# Altium schematic sheet ARK_Cannode_Microcontroller.SchDoc(ARK_Cannode_Microcontroller)
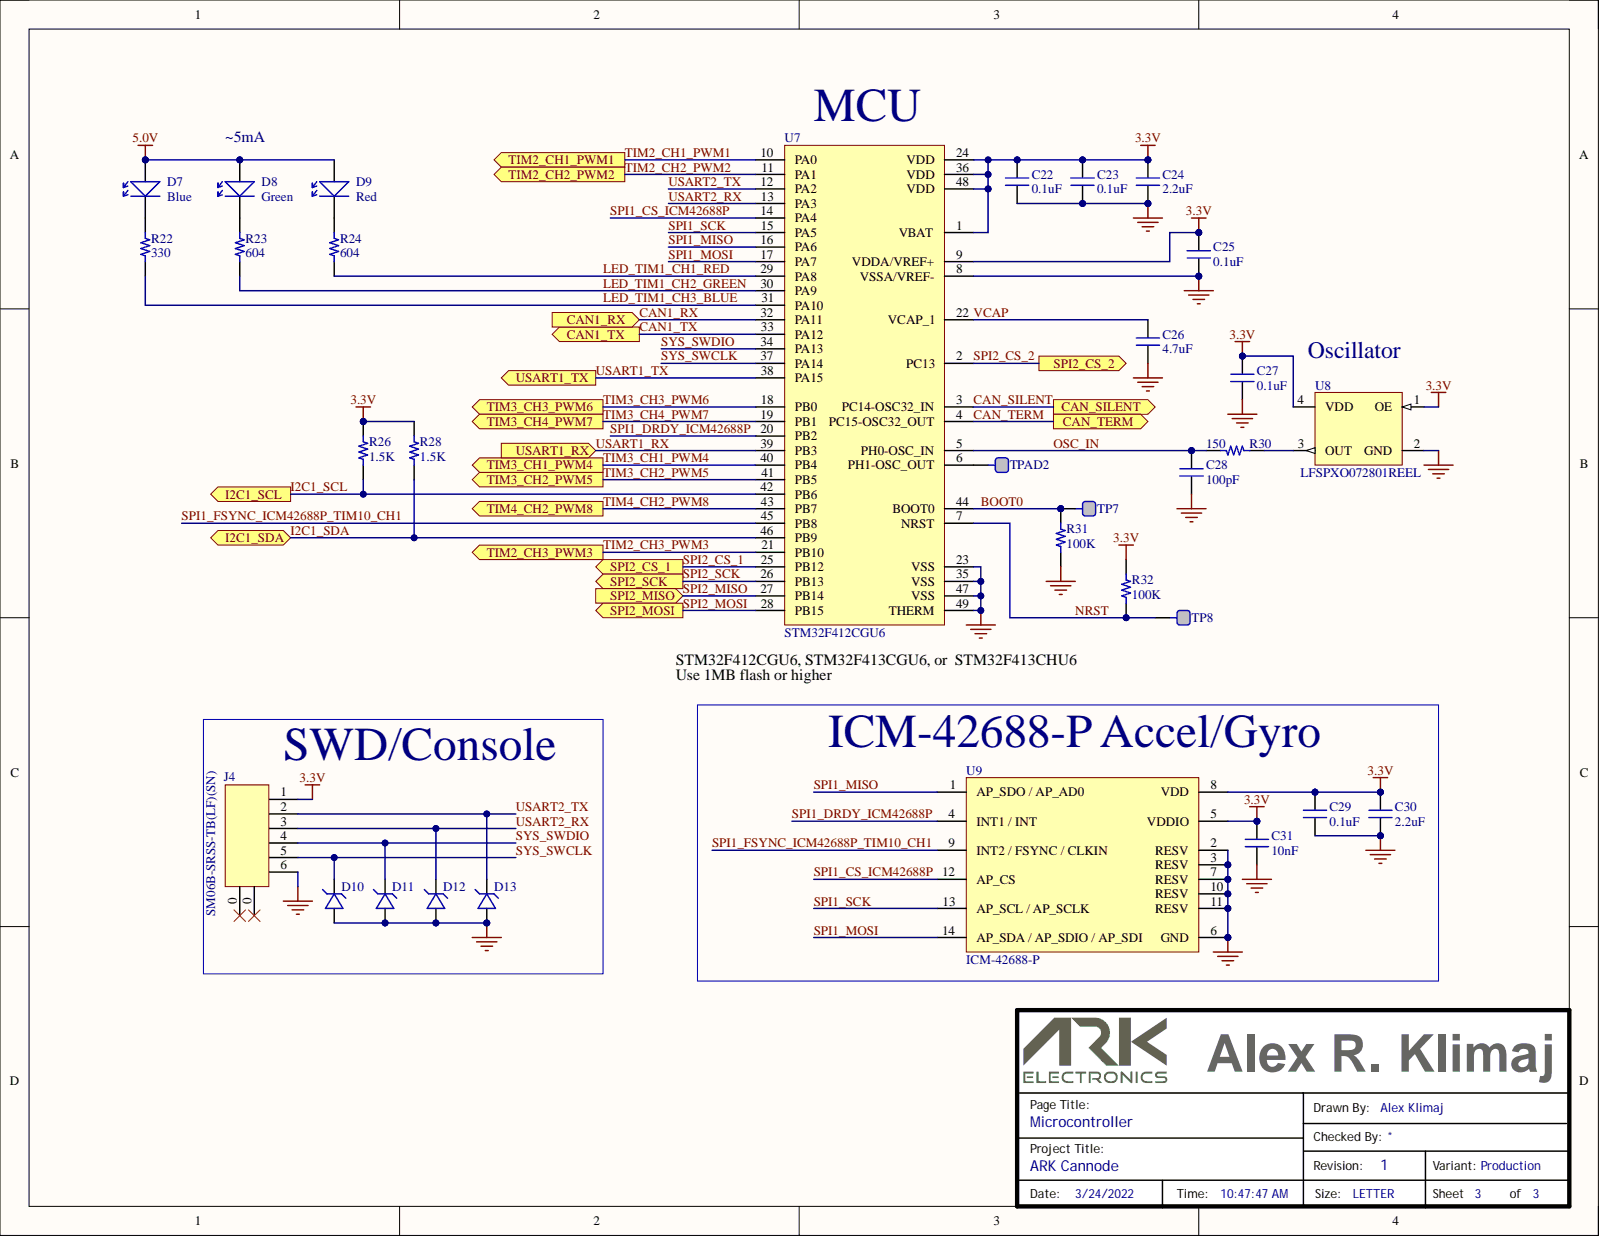
[diagram p0: ARK_Cannode_Microcontroller.SchDoc]

## Parts
C22  value 0.1uF  [CAP 0402/1005]
C23  value 0.1uF  [CAP 0402/1005]
C24  2.2uF  [CAP 0402/1005]
C25  value 0.1uF  [CAP 0402/1005]
C26  4.7uF  [CAP 0402/1005]
C27  value 0.1uF  [CAP 0402/1005]
C28  100pF  [CAP 0402/1005]
C29  value 0.1uF  [CAP 0402/1005]
C30  2.2uF  [CAP 0402/1005]
C31  10nF  [CAP 0402/1005]
D7  LTST-C194TBKT  [LTST-C191TBKT]  (value not drawn)
D8  LTST-C191KGKT  [LTST-C191TBKT]  (value not drawn)
D9  LTST-C190KRKT  [LTST-C191TBKT]  (value not drawn)
D10  (no value)  [SOD-923]
D11  (no value)  [SOD-923]
D12  (no value)  [SOD-923]
D13  (no value)  [SOD-923]
J4  value SM06B-SRSS-TB(LF)(SN)  [SM06B-SRSS-TB(LF)(SN)]
R22  330  [RESC0402(1005)_N]
R23  604  [RESC0402(1005)_N]
R24  604  [RESC0402(1005)_N]
R26  1.5K  [RESC0402(1005)_N]
R28  1.5K  [RESC0402(1005)_N]
R30  150  [RESC0402(1005)_N]
R31  100K  [RESC0402(1005)_N]
R32  100K  [RESC0402(1005)_N]
TP7  (no value)  [TEST_PAD]  (no BOM)
TP8  (no value)  [TEST_PAD]  (no BOM)
TPAD2  (no value)  [TEST_PAD]  (no BOM)
U7  STM32F412CGU6  Sub_Type: MCU  [UFQFPN48]
U8  LFSPXO072801REEL  Voltage_Rating: 3.3V  [LFSPXO072801REEL]
U9  ICM-42688-P  [ICM-42688-P]

## Ports
CAN1_RX, CAN1_TX, CAN_SILENT, CAN_TERM, I2C1_SCL, I2C1_SDA, SPI2_CS_1, SPI2_CS_2, SPI2_MISO, SPI2_MOSI, SPI2_SCK, TIM2_CH1_PWM1, TIM2_CH2_PWM2, TIM2_CH3_PWM3, TIM3_CH1_PWM4, TIM3_CH2_PWM5, TIM3_CH3_PWM6, TIM3_CH4_PWM7, TIM4_CH2_PWM8, USART1_RX, USART1_TX

## Nets
3.3V: C22.2 C23.2 C24.2 C25.2 C27.2 C29.2 C30.2 C31.2 J4.1 R26.2 R28.2 R32.2 U7.1(VBAT) U7.9(VDDA/VREF+) U7.24(VDD) U7.36(VDD) U7.48(VDD) U8.1(OE) U8.4(VDD) U9.5(VDDIO) U9.8(VDD)
5.0V: D7.2 D8.2 D9.2
GND: C22.1 C23.1 C24.1 C25.1 C26.1 C27.1 C28.1 C29.1 C30.1 C31.1 D10.2 D11.2 D12.2 D13.2 J4.6 R31.1 U7.8(VSSA/VREF-) U7.23(VSS) U7.35(VSS) U7.47(VSS) U7.49(THERM) U8.2(GND) U9.2(RESV) U9.3(RESV) U9.6(GND) U9.7(RESV) U9.10(RESV) U9.11(RESV)
BOOT0: R31.2 TP7.1 U7.44(BOOT0)
CAN1_RX: U7.32(PA11) ; port CAN1_RX
CAN1_TX: U7.33(PA12) ; port CAN1_TX
CAN_SILENT: U7.3(PC14-OSC32_IN) ; port CAN_SILENT
CAN_TERM: U7.4(PC15-OSC32_OUT) ; port CAN_TERM
I2C1_SCL: R26.1 U7.42(PB6) ; port I2C1_SCL
I2C1_SDA: R28.1 U7.46(PB9) ; port I2C1_SDA
LED_TIM1_CH1_RED: R24.1 U7.29(PA8)
LED_TIM1_CH2_GREEN: R23.1 U7.30(PA9)
LED_TIM1_CH3_BLUE: R22.1 U7.31(PA10)
NRST: R32.1 TP8.1 U7.7(NRST)
OSC_IN: C28.2 R30.1 U7.5(PH0-OSC_IN)
SPI1_CS_ICM42688P: U7.14(PA4) U9.12(AP_CS)
SPI1_DRDY_ICM42688P: U7.20(PB2) U9.4(INT1_/_INT)
SPI1_FSYNC_ICM42688P_TIM10_CH1: U7.45(PB8) U9.9
SPI1_MISO: U7.16(PA6) U9.1(AP_SDO_/_AP_AD0)
SPI1_MOSI: U7.17(PA7) U9.14
SPI1_SCK: U7.15(PA5) U9.13(AP_SCL_/_AP_SCLK)
SPI2_CS_1: U7.25(PB12) ; port SPI2_CS_1
SPI2_CS_2: U7.2(PC13) ; port SPI2_CS_2
SPI2_MISO: U7.27(PB14) ; port SPI2_MISO
SPI2_MOSI: U7.28(PB15) ; port SPI2_MOSI
SPI2_SCK: U7.26(PB13) ; port SPI2_SCK
SYS_SWCLK: D10.1 J4.5 U7.37(PA14)
SYS_SWDIO: D11.1 J4.4 U7.34(PA13)
TIM2_CH1_PWM1: U7.10(PA0) ; port TIM2_CH1_PWM1
TIM2_CH2_PWM2: U7.11(PA1) ; port TIM2_CH2_PWM2
TIM2_CH3_PWM3: U7.21(PB10) ; port TIM2_CH3_PWM3
TIM3_CH1_PWM4: U7.40(PB4) ; port TIM3_CH1_PWM4
TIM3_CH2_PWM5: U7.41(PB5) ; port TIM3_CH2_PWM5
TIM3_CH3_PWM6: U7.18(PB0) ; port TIM3_CH3_PWM6
TIM3_CH4_PWM7: U7.19(PB1) ; port TIM3_CH4_PWM7
TIM4_CH2_PWM8: U7.43(PB7) ; port TIM4_CH2_PWM8
USART1_RX: U7.39(PB3) ; port USART1_RX
USART1_TX: U7.38(PA15) ; port USART1_TX
USART2_RX: D12.1 J4.3 U7.13(PA3)
USART2_TX: D13.1 J4.2 U7.12(PA2)
VCAP: C26.2 U7.22(VCAP_1)
NetD7_1: D7.1 R22.2
NetD8_1: D8.1 R23.2
NetD9_1: D9.1 R24.2
NetR30_2: R30.2 U8.3(OUT)
NetTPAD2_1: TPAD2.1 U7.6(PH1-OSC_OUT)

Not connected: J4 0

## Library data of the parts
C22 C23 C25 C27 C29 = 30007: SMT Capacitor; Manufacturer: Walsin Technology Corporation; Mfr Part Description: CAP CER 0.1uF ±10% 25V X5R 0402 (1005 Metric); Mfr Part Number: 0402X104K250CT; Database Table Name: Parts; Dielectric: X5R; Tolerance: ±10%; Updated By: aklimaj; Voltage_Rating: 25V; Component Kind: Standard; ComponentLink1Description: Datasheet; ComponentLink1URL: http://www.passivecomponent.com/wp-content/uploads/2018/10/MLCC.pdf; ComponentLink2Description: Supplier Part Details; ComponentLink2URL: https://www.digikey.com/products/en?keywords=0402X104K250CT; Created_Date: 2017/07/11 17:31:38; ID: 30007; Library Name: Parts.DbLib; LifeCycle: New; Package_Case: 0402 (1005 Metric); Part_Type: Capacitor; RoHS: Yes; Supplier: Digi-Key; Supplier Part Number: 1292-1640-2-ND; Temperature Range: -55C to +85C; Updated_Date: 2020/12/17 20:30:00; Created By: aklimaj
C24 C30 = 30151: SMT Capacitor; Manufacturer: Murata; Manufacturer Alt 1: Taiyo Yuden; Mfr Part Description: CAP CER 2.2uF ±20% 10V X5R 0402 (1005 Metric); Mfr Part Number: GRM155R61A225ME95D; Mfr Part Number Alt 1: LMK105BJ225MV-F; Database Table Name: Parts; Dielectric: X5R; Tolerance: ±20%; Updated By: aklimaj; Voltage_Rating: 10V; Component Kind: Standard; ComponentLink1Description: Datasheet; ComponentLink1URL: http://search.murata.co.jp/Ceramy/image/img/A01X/partnumbering_e_01.pdf; ComponentLink2Description: Supplier Part Details; ComponentLink2URL: https://www.digikey.com/product-detail/en/murata-electronics-north-america/GRM155R61A225ME95D/490-10452-2-ND/5027537; Created_Date: 2017/11/02 14:41:38; ID: 30151; Library Name: Parts.DbLib; LifeCycle: New; Package_Case: 0402 (1005 Metric); Part_Type: Capacitor; RoHS: Yes; Supplier: Digi-Key; Supplier Part Number: 490-10452-2-ND; Temperature Range: -55C to +85C; Updated_Date: 2021/09/10 21:38:25; Value: 2.2uF; Created By: aklimaj
C26 = 30302: SMT Capacitor; Manufacturer: TDK Corporation; Manufacturer Alt 1: Venkel; Mfr Part Description: CAP CER 4.7uF ±10% 6.3V X5R 0402 (1005 Metric); Mfr Part Number: C1005X5R0J475K050BC; Mfr Part Number Alt 1: C0402X5R6R3-475KNP-CT; Database Table Name: Parts; Dielectric: X5R; Tolerance: ±10%; Updated By: aklimaj; Voltage_Rating: 6.3V; Component Kind: Standard; ComponentLink1Description: Datasheet; ComponentLink1URL: https://product.tdk.com/info/en/catalog/datasheets/mlcc_commercial_general_en.pdf; ComponentLink2Description: Supplier Part Details; ComponentLink2URL: https://www.digikey.com/products/en?keywords=445-5947-1-ND&stock=1; Created_Date: 2019/02/28 17:07:10; ID: 30302; Library Name: Parts.DbLib; LifeCycle: New; Manufacturer Alt 2: AVX Corporation; Mfr Part Number Alt 2: 04026D475KAT2A; Package_Case: 0402 (1005 Metric); Part_Type: Capacitor; RoHS: Yes; Supplier: Digi-Key; Supplier Part Number: 445-5947-1-ND; Temperature Range: -55C to +85C; Updated_Date: 2021/08/05 14:56:58; Value: 4.7uF; Created By: aklimaj
C28 = 30502: SMT Capacitor; Manufacturer: Samsung Electro-Mechanics; Mfr Part Description: CAP CER 100pF ±5% 50V NPO 0402 (1005 Metric); Mfr Part Number: CL05C101JB5NNNC; Database Table Name: Parts; Dielectric: NPO; Tolerance: ±5%; Updated By: aklimaj; Voltage_Rating: 50V; Component Kind: Standard; ComponentLink1Description: Datasheet; ComponentLink1URL: http://www.samsungsem.com/kr/support/product-search/mlcc/CL05C101JB5NNNC.jsp; ComponentLink2Description: Supplier Part Details; ComponentLink2URL: https://www.digikey.com/product-detail/en/samsung-electro-mechanics/CL05C101JB5NNNC/1276-1025-1-ND/3889111; Created_Date: 2019/07/13 19:13:16; ID: 30502; Library Name: Parts.DbLib; LifeCycle: New; Package_Case: 0402 (1005 Metric); Part_Type: Capacitor; RoHS: Yes; Supplier: Digi-Key; Supplier Part Number: 1276-1025-1-ND; Temperature Range: -55C to +125C; Updated_Date: 2021/09/10 11:27:15; Value: 100pF; Created By: aklimaj
C31 = 30018: SMT Capacitor; Manufacturer: Samsung; Manufacturer Alt 1: YAGEO; Mfr Part Description: CAP CER 10000pF ±10% 25V X7R 0402 (1005 Metric); Mfr Part Number: CL05B103KA5NNNC; Mfr Part Number Alt 1: CC0402KRX7R8BB103; Database Table Name: Parts; Dielectric: X7R; Tolerance: ±10%; Updated By: aklimaj; Voltage_Rating: 25V; Component Kind: Standard; ComponentLink1Description: Datasheet; ComponentLink1URL: http://www.samsungsem.com/kr/support/product-search/mlcc/__icsFiles/afieldfile/2016/08/18/S_CL05B103KA5NNNC.pdf; ComponentLink2Description: Supplier Part Details; ComponentLink2URL: https://www.digikey.com/product-detail/en/samsung-electro-mechanics-america-inc/CL05B103KA5NNNC/1276-1057-1-ND/3889143; Created_Date: 2017/07/13 10:07:08; ID: 30018; Library Name: Parts.DbLib; LifeCycle: New; Package_Case: 0402 (1005 Metric); Part_Type: Capacitor; RoHS: Yes; Supplier: Digi-Key; Supplier Part Number: 1276-1057-1-ND; Temperature Range: -55C to +125C; Updated_Date: 2021/09/15 13:17:34; Value: 10nF; Created By: aklimaj
D7 = 30059: LTST-C191TBKT LED BLUE CLEAR 0603 SMD; Manufacturer: Lite-On Inc.; Mfr Part Description: LED BLUE CLEAR SMD; Part_Type: Discrete; Current_Rating: 20mA; Database Table Name: Parts; Notes: Was Mfr P/N LTST-C191TBKT; Updated By: aklimaj; Voltage_Rating: 3.3V; Component Kind: Standard; ComponentLink1Description: Datasheet; ComponentLink1URL: https://optoelectronics.liteon.com/upload/download/DS22-2010-0025/LTST-C194TBKT.PDF; ComponentLink2Description: Supplier Part Details; ComponentLink2URL: https://www.digikey.com/products/en?keywords=LTST-C194TBKT; Created_Date: 2017/09/05 17:40:57; ID: 30059; Library Name: Parts.DbLib; LifeCycle: New; Mfr Part Number: LTST-C194TBKT; Package_Case: 0603 (1608 Metric); RoHS: Yes; Supplier: Digi-Key; Supplier Part Number: 160-1837-2-ND; Updated_Date: 2021/09/10 21:26:14; Value: LTST-C194TBKT; Created By: aklimaj
D8 = 30628: LTST-C191TBKT LED BLUE CLEAR 0603 SMD; Manufacturer: Lite-On Inc.; Manufacturer Alt 1: Wurth; Mfr Part Description: Green 571nm LED Indication - Discrete 2V 0603 (1608 Metric); Mfr Part Number Alt 1: 150060VS75000; Part_Type: Discrete; Current_Rating: 20mA; Database Table Name: Parts; Updated By: aklimaj; Voltage_Rating: 2V; Component Kind: Standard; ComponentLink1Description: Datasheet; ComponentLink1URL: http://optoelectronics.liteon.com/upload/download/DS22-2000-228/LTST-C191KGKT.PDF; ComponentLink2Description: Supplier Part Details; ComponentLink2URL: https://www.digikey.com/product-detail/en/lite-on-inc/LTST-C191KGKT/160-1446-1-ND/386834; Created_Date: 2019/11/13 17:03:39; ID: 30628; Library Name: Parts.DbLib; LifeCycle: New; Mfr Part Number: LTST-C191KGKT; Package_Case: 0603 (1608 Metric); RoHS: Yes; Supplier: Digi-Key; Supplier Part Number: 160-1446-1-ND; Updated_Date: 2021/03/27 12:39:10; Value: LTST-C191KGKT; Created By: aklimaj
D9 = 30943: Manufacturer: Lite-On Inc.; Manufacturer Alt 1: Everlight; Mfr Part Description: LED RED CLEAR CHIP SMD; Mfr Part Number Alt 1: EAST16084RA0; Part_Type: Discrete; Current_Rating: 20mA; Database Table Name: Parts; Updated By: aklimaj; Voltage_Rating: 2V; Component Kind: Standard; ComponentLink1Description: Datasheet; ComponentLink1URL: http://optoelectronics.liteon.com/upload/download/DS-22-99-0151/LTST-C190KRKT.pdf; ComponentLink2Description: Supplier Part Details; ComponentLink2URL: https://www.digikey.com/products/en?keywords=LTST-C190KRKT; Created_Date: 2020/12/11 17:10:57; ID: 30943; Library Name: Parts.DbLib; LifeCycle: New; Manufacturer Alt 2: Lite-On Inc.; Mfr Part Number: LTST-C190KRKT; Mfr Part Number Alt 2: LTST-C191KRKT; Package_Case: 0603 (1608 Metric); RoHS: Yes; Supplier: Digi-Key; Supplier Part Number: 160-1436-2-ND; Updated_Date: 2021/04/09 09:54:26; Value: LTST-C190KRKT; Created By: aklimaj
D10-D13 = 30166: TVS Diode; Manufacturer: ON Semiconductor; Mfr Part Description: TVS DIODE 3.3VWM SOD923; Mfr Part Number: ESD9C3.3ST5G; Part_Type: Protection; Database Table Name: Parts; Updated By: aklimaj; Value: ESD9C3.3ST5G; Voltage_Rating: 3.3V (Max); Component Kind: Standard; ComponentLink1Description: Datasheet; ComponentLink1URL: http://www.onsemi.com/pub/Collateral/ESD9C3.3S-D.PDF; ComponentLink2Description: Supplier Part Details; ComponentLink2URL: https://www.digikey.com/product-detail/en/on-semiconductor/ESD9C3.3ST5G/ESD9C3.3ST5GOSCT-ND/1646498; Created_Date: 2017/12/13 10:54:56; ID: 30166; Library Name: Parts.DbLib; LifeCycle: New; Package_Case: SOD-923; RoHS: Yes; Supplier: Digi-Key; Supplier Part Number: ESD9C3.3ST5GOSCT-ND; Temperature Range: -55C to +150C (TJ); Updated_Date: 2018/10/19 21:38:12; Created By: aklimaj
J4 = 30085: CONN HEADER SH 6POS SIDE 1MM TIN; Manufacturer: JST Sales America Inc.; Part_Type: Connector; Current_Rating: 0.7A; Database Table Name: Parts; Updated By: aklimaj; Voltage_Rating: 50V; Component Kind: Standard; ComponentLink1Description: Datasheet; ComponentLink1URL: http://www.jst-mfg.com/product/pdf/eng/eSH.pdf; ComponentLink2Description: Supplier Part Details; ComponentLink2URL: https://www.digikey.com/product-detail/en/jst-sales-america-inc/SM06B-SRSS-TB(LF)(SN)/455-1806-1-ND/926877; Created_Date: 2017/09/13 10:26:52; ID: 30085; Library Name: Parts.DbLib; LifeCycle: New; Mfr Part Description: CONN HEADER SH 6POS SIDE 1MM TIN; Mfr Part Number: SM06B-SRSS-TB(LF)(SN); RoHS: Yes; Supplier: Digi-Key; Supplier Part Number: 455-1806-1-ND; Temperature Range: -25C to +85C; Updated_Date: 2018/10/19 21:38:32; Created By: aklimaj
R22 = 30681: SMT Resistor; Manufacturer: Yageo; Mfr Part Description: RES 330 Ohm ±1% 1/16W 0402 (1005 Metric); Mfr Part Number: RC0402FR-07330RL; Database Table Name: Parts; Power_Rating: 0.063W, 1/16W; Temperature Coeff: ±100ppm/degC; Tolerance: ±1%; Updated By: aklimaj; Component Kind: Standard; ComponentLink1Description: Datasheet; ComponentLink1URL: https://www.yageo.com/upload/media/product/productsearch/datasheet/rchip/PYu-RC_Group_51_RoHS_L_10.pdf; ComponentLink2Description: Supplier Part Details; ComponentLink2URL: https://www.digikey.com/product-detail/en/yageo/RC0402FR-07330RL/311-330LRCT-ND/729541; Created_Date: 2019/12/02 20:26:12; ID: 30681; Library Name: Parts.DbLib; LifeCycle: New; Package_Case: 0402 (1005 Metric); Part_Type: Resistor; RoHS: Yes; Supplier: Digi-Key; Supplier Part Number: 311-330LRCT-ND; Temperature Range: -55C to +155C; Updated_Date: 2019/12/02 20:28:13; Value: 330; Created By: aklimaj
R23 R24 = 30838: SMT Resistor; Manufacturer: Stackpole Electronics Inc; Mfr Part Description: RES 604 Ohm ±1% 1/16W 0402 (1005 Metric); Mfr Part Number: RMCF0402FT604R; Database Table Name: Parts; Power_Rating: 0.063W, 1/16W; Temperature Coeff: ±100ppm/degC; Tolerance: ±1%; Updated By: aklimaj; Component Kind: Standard; ComponentLink1Description: Datasheet; ComponentLink1URL: https://www.seielect.com/catalog/sei-rmcf_rmcp.pdf; ComponentLink2Description: Supplier Part Details; ComponentLink2URL: https://www.digikey.com/product-detail/en/stackpole-electronics-inc/RMCF0402FT604R/RMCF0402FT604RCT-ND/6052942; Created_Date: 2020/07/23 10:53:25; ID: 30838; Library Name: Parts.DbLib; LifeCycle: New; Package_Case: 0402 (1005 Metric); Part_Type: Resistor; RoHS: Yes; Supplier: Digi-Key; Supplier Part Number: RMCF0402FT604RCT-ND; Temperature Range: -55C to +155C; Updated_Date: 2020/07/23 10:53:37; Value: 604; Created By: aklimaj
R26 R28 = 30457: SMT Resistor; Manufacturer: Stackpole Electronics Inc; Mfr Part Description: RES 1.5K Ohm ±1% 1/16W 0402 (1005 Metric); Mfr Part Number: RMCF0402FT1K50; Database Table Name: Parts; Power_Rating: 0.063W, 1/16W; Temperature Coeff: ±100ppm/degC; Tolerance: ±1%; Updated By: aklimaj; Component Kind: Standard; ComponentLink1Description: Datasheet; ComponentLink1URL: https://www.seielect.com/catalog/sei-rmcf_rmcp.pdf; ComponentLink2Description: Supplier Part Details; ComponentLink2URL: https://www.digikey.com/product-detail/en/stackpole-electronics-inc/RMCF0402FT1K50/RMCF0402FT1K50CT-ND/1942921; Created_Date: 2019/05/29 12:51:28; ID: 30457; Library Name: Parts.DbLib; LifeCycle: New; Package_Case: 0402 (1005 Metric); Part_Type: Resistor; RoHS: Yes; Supplier: Digi-Key; Supplier Part Number: RMCF0402FT1K50CT-ND; Temperature Range: -55C to +155C; Updated_Date: 2019/05/29 12:51:50; Value: 1.5K; Created By: aklimaj
R30 = 30328: SMT Resistor; Manufacturer: Yageo; Mfr Part Description: RES 150 Ohm ±1% 1/16W 0402 (1005 Metric); Mfr Part Number: RC0402FR-07150RL; Database Table Name: Parts; Power_Rating: 0.063W, 1/16W; Temperature Coeff: ±100ppm/degC; Tolerance: ±1%; Updated By: aklimaj; Component Kind: Standard; ComponentLink1Description: Datasheet; ComponentLink1URL: https://www.yageo.com/upload/media/product/productsearch/datasheet/rchip/PYu-RC_Group_51_RoHS_L_11.pdf; ComponentLink2Description: Supplier Part Details; ComponentLink2URL: https://www.digikey.com/en/products/detail/yageo/RC0402FR-07150RL/726543; Created_Date: 2019/02/28 18:44:46; ID: 30328; Library Name: Parts.DbLib; LifeCycle: New; Package_Case: 0402 (1005 Metric); Part_Type: Resistor; RoHS: Yes; Supplier: Digi-Key; Supplier Part Number: 311-150LRTR-ND; Temperature Range: -55C to +155C; Updated_Date: 2021/05/26 09:40:11; Value: 150; Created By: aklimaj
R31 R32 = 30333: SMT Resistor; Manufacturer: Yageo; Mfr Part Description: RES 100K Ohm ±5% 1/16W 0402 (1005 Metric); Mfr Part Number: AC0402JR-07100KL; Database Table Name: Parts; Power_Rating: 0.063W, 1/16W; Temperature Coeff: ±100ppm/degC; Tolerance: ±5%; Updated By: aklimaj; Component Kind: Standard; ComponentLink1Description: Datasheet; ComponentLink1URL: https://www.yageo.com/upload/media/product/productsearch/datasheet/rchip/PYu-AC_51_RoHS_L_7.pdf; ComponentLink2Description: Supplier Part Details; ComponentLink2URL: https://www.digikey.com/products/en?keywords=AC0402JR-07100KL; Created_Date: 2019/02/28 18:55:55; ID: 30333; Library Name: Parts.DbLib; LifeCycle: New; Package_Case: 0402 (1005 Metric); Part_Type: Resistor; RoHS: Yes; Supplier: Digi-Key; Supplier Part Number: YAG3515TR-ND; Temperature Range: -55C to +155C; Updated_Date: 2021/09/22 12:12:03; Value: 100K; Created By: aklimaj
TP7 TP8 TPAD2 = 30015: TEST_PAD; Mfr Part Description: Test Point; Database Table Name: Parts; Updated By: aklimaj; ComponentLink1Description: Datasheet; ComponentLink2Description: Supplier Part Details; Created_Date: 2017/07/13 09:26:35; ID: 30015; Library Name: Parts.DbLib; LifeCycle: New; Part_Type: Test Point; RoHS: Yes; Updated_Date: 2019/07/19 12:21:04; Created By: aklimaj
U7 = 31237: Manufacturer: STMicroelectronics; Mfr Part Description: IC MCU 32BIT 1MB FLASH 48UFQFPN; Part_Type: IC; Database Table Name: Parts; Updated By: aklimaj; Component Kind: Standard; ComponentLink1Description: Datasheet; ComponentLink1URL: https://www.st.com/content/ccc/resource/technical/document/datasheet/15/90/07/b3/8c/10/4e/95/DM00213872.pdf/files/DM00213872.pdf/jcr:content/translations/en.DM00213872.pdf; ComponentLink2Description: Supplier Part Details; ComponentLink2URL: https://www.digikey.com/products/en?keywords=497-16763-ND; Created_Date: 2022-03-24 10:45:10; ID: 31237; Library Name: Parts.DbLib; LifeCycle: New; Mfr Part Number: STM32F412CGU6; Package_Case: 48-UFQFN Exposed Pad; RoHS: Yes; Supplier: Digi-Key; Supplier Part Number: 497-16763-ND; Temperature Range: -40C to +85C (TA); Updated_Date: 2022-03-24 10:45:43; Value: STM32F412CGU6; Created By: aklimaj
U8 = 31087: Manufacturer: IQD Frequency Products; Manufacturer Alt 1: ECS; Mfr Part Description: XTAL OSC XO 8.0000MHZ HCMOS SMD; Mfr Part Number Alt 1: ECS-2520MV-080-CN-TR; Part_Type: XTAL; Current_Rating: 10mA; Database Table Name: Parts; Updated By: aklimaj; Component Kind: Standard; ComponentLink1Description: Datasheet; ComponentLink1URL: https://www.iqdfrequencyproducts.com/products/pn/LFSPXO072801Reel.pdf; ComponentLink2Description: Supplier Part Details; ComponentLink2URL: https://www.digikey.com/en/products/detail/iqd-frequency-products/LFSPXO072801REEL/8633968; Created_Date: 2021/05/14 12:40:09; ID: 31087; Library Name: Parts.DbLib; LifeCycle: New; Mfr Part Number: LFSPXO072801REEL; Package_Case: 4-SMD, No Lead; RoHS: Yes; Supplier: Digi-Key; Supplier Part Number: 1923-1681-2-ND; Temperature Range: -40C to +85C; Updated_Date: 2021/11/11 10:18:46; Value: LFSPXO072801REEL; Created By: aklimaj
U9 = 31021: Manufacturer: TDK InvenSense; Mfr Part Description: MOTION SENSOR; Part_Type: MOTION; Database Table Name: Parts; Updated By: aklimaj; Component Kind: Standard; ComponentLink1Description: Datasheet; ComponentLink1URL: https://media.digikey.com/pdf/Data%20Sheets/TDK%20PDFs/ICM-42688-P_DS_Rev1.2.pdf; ComponentLink2Description: Supplier Part Details; ComponentLink2URL: https://www.digikey.com/products/en?keywords=ICM-42688-P; Created_Date: 2021/03/26 20:29:47; ID: 31021; Library Name: Parts.DbLib; LifeCycle: New; Mfr Part Number: ICM-42688-P; RoHS: Yes; Supplier: Digi-Key; Supplier Part Number: 1428-ICM-42688-PTR-ND; Updated_Date: 2021/03/26 20:53:04; Value: ICM-42688-P; Created By: aklimaj
